# Altium schematic sheet trailGuard.SchDoc
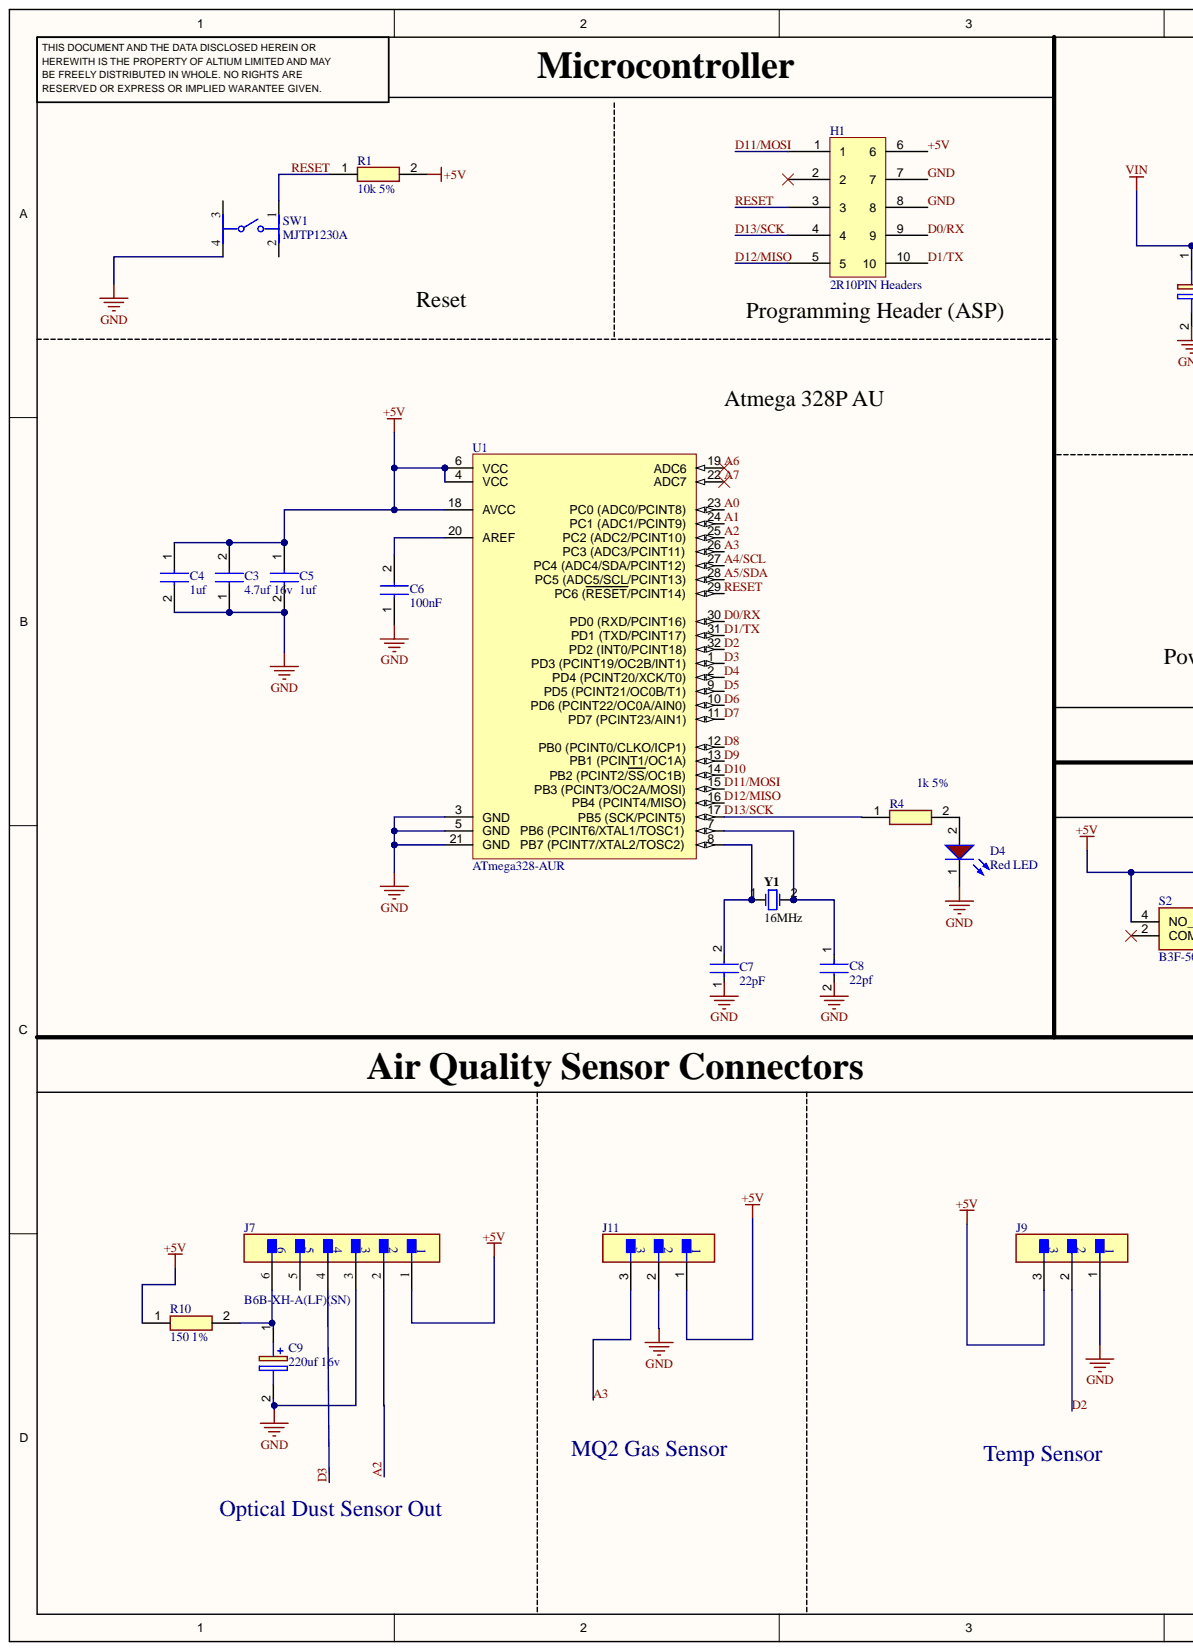
[diagram p0: trailGuard.SchDoc, left]
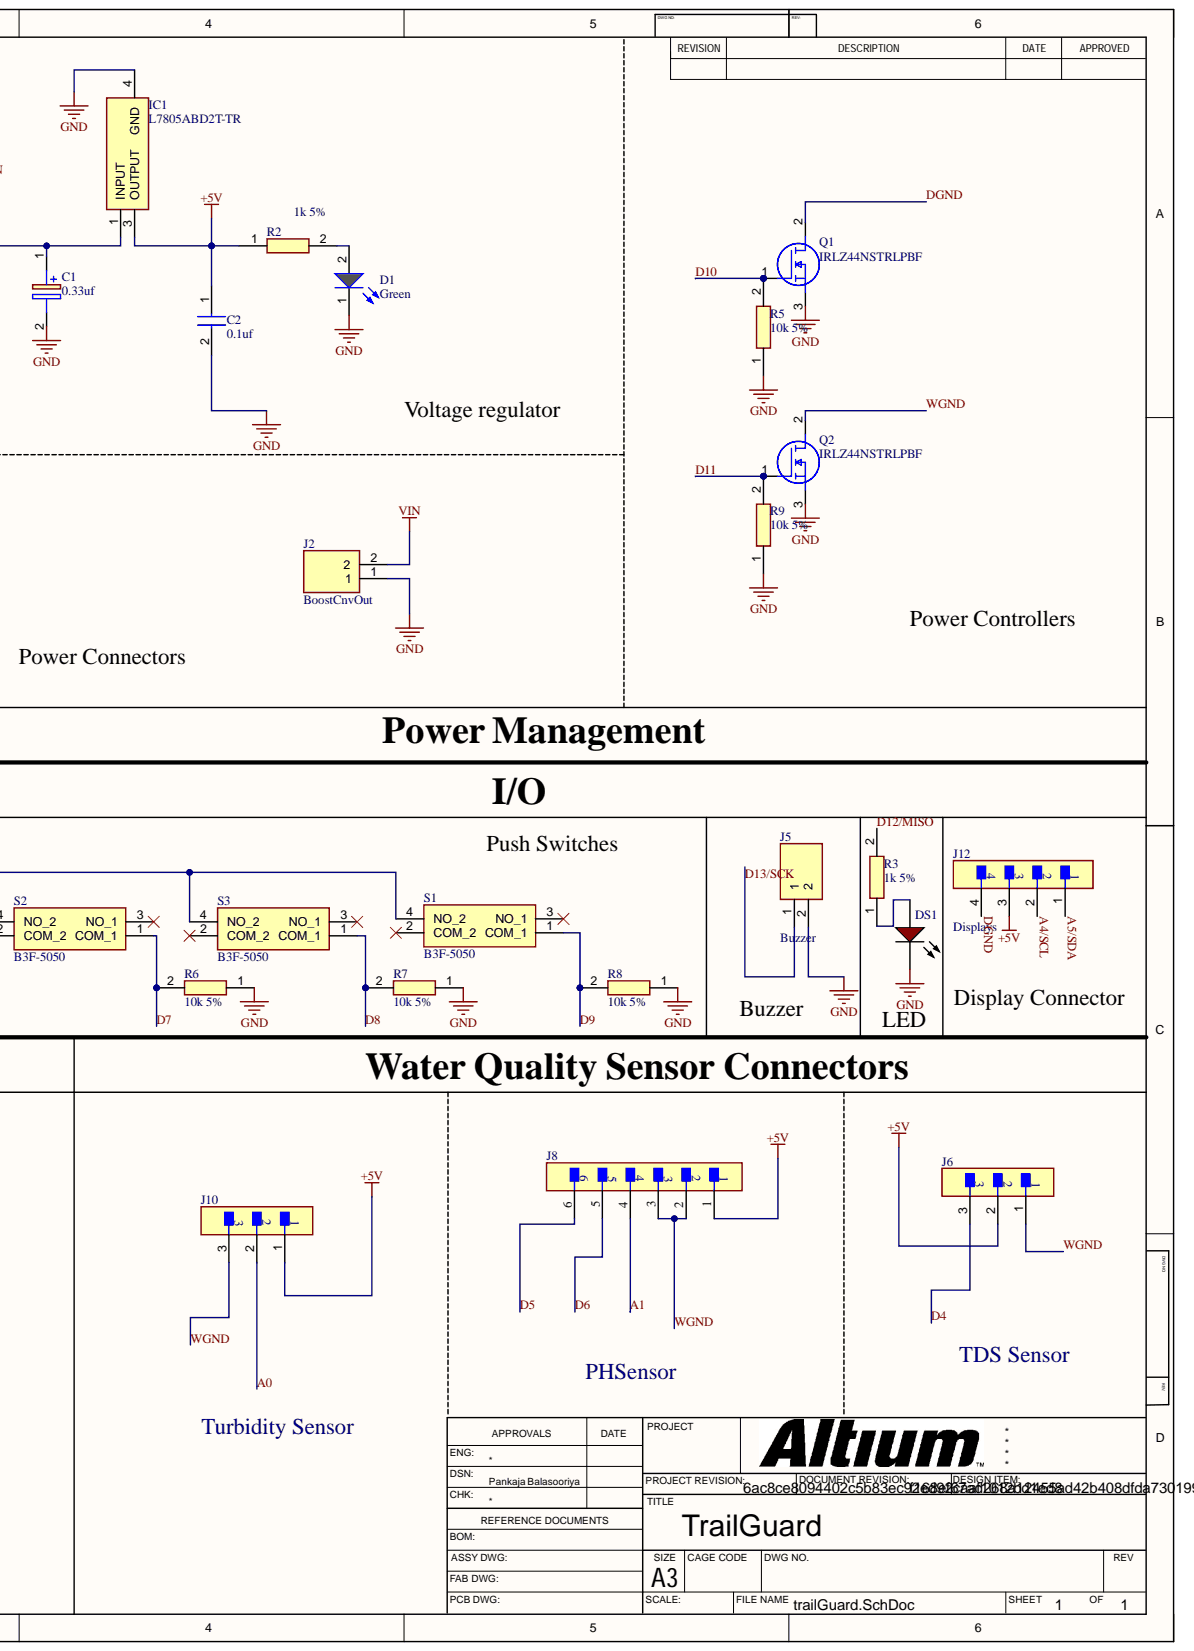
[diagram p1: trailGuard.SchDoc, right]

## Parts
C1  0.33uf  [CAPPM3216X180N]
C2  0.1uf  [C1206]
C3  4.7uf 16v  [CAPC3216X180N]
C4  1uf  [C1206]
C5  1uf  [C1206]
C6  100nF  [CAPC3216X190N]
C7  22pF  [FP-CL21-IPC_C]
C8  22pf  [FP-CL21-IPC_C]
C9  220uf 16v  [EEE0JA331XP]
D1  Green  [WL-SMCW_0805_150080xx75000]
D4  Red LED  Color: Red  [WL-SMCW_0805_150080xx75000]
DS1  HLMP-3301  [AVAG-HLMP-3301-2]  (value not drawn)
H1  2R10PIN Headers  [2R10PIN Headers]
IC1  L7805ABD2T-TR  [D2PAK-STB35NF10T4]
J2  BoostCnvOut  [B2BXHAMLFSN]
J5  Buzzer  [B2BXHAMLFSN]
J6  B3B-XH-A(LF)(SN)  [FP-B3B-XH-A_LF_SN-MFG]  (value not drawn)
J7  B6B-XH-A(LF)(SN)  [FP-B6B-XH-A_LF_SN-MFG]
J8  B6B-XH-A(LF)(SN)  [FP-B6B-XH-A_LF_SN-MFG]
J9  B3B-XH-A(LF)(SN)  [FP-B3B-XH-A_LF_SN-MFG]  (value not drawn)
J10  B3B-XH-A(LF)(SN)  [FP-B3B-XH-A_LF_SN-MFG]  (value not drawn)
J11  B3B-XH-A(LF)(SN)  [FP-B3B-XH-A_LF_SN-MFG]  (value not drawn)
J12  Displays  [FP-B4B-XH-AM_LF_SN-MFG]
Q1  IRLZ44NSTRLPBF  [IRF2804STRLPBF]
Q2  IRLZ44NSTRLPBF  [IRF2804STRLPBF]
R1  10k 5%  [RESC3116X70N]
R2  1k 5%  [RESC3116X70N]
R3  1k 5%  [RESC3116X70N]
R4  1k 5%  [RESC3116X70N]
R5  10k 5%  [RESC3116X70N]
R6  10k 5%  [RESC3116X70N]
R7  10k 5%  [RESC3116X70N]
R8  10k 5%  [RESC3116X70N]
R9  10k 5%  [RESC3116X70N]
R10  150 1%  [ERJ8ENF1000V]
S1  B3F-5050  [B3F-4055]
S2  B3F-5050  [B3F-4055]
S3  B3F-5050  [B3F-4055]
SW1  MJTP1230A  [FP-MJTP1230A-MFG]
U1  ATmega328-AUR  [32A_L]
Y1  16MHz  [FOX-FOXSLF160-20]

## Nets
VIN: C1.1 IC1.1 J2.2
+5V: C2.1 C3.2 C4.1 C5.1 H1.6 IC1.3 J6.2 J7.1 J8.1 J9.3 J10.1 J11.1 J12.3 R1.2 R2.1 R10.1 S1.4(NO_2) S2.4(NO_2) S3.4(NO_2) U1.4(VCC) U1.6(VCC) U1.18(AVCC)
A0: J10.2 U1.23
A1: J8.4 U1.24(PC1_(ADC1/PCINT9))
A2: J7.2 U1.25
A3: J11.3 U1.26(PC3_(ADC3/PCINT11))
A4/SCL: J12.2 U1.27(PC4_(ADC4/SDA/PCINT12))
A5/SDA: J12.1 U1.28(PC5_(ADC5/SCL/PCINT13))
A6: U1.19(ADC6)
A7: U1.22(ADC7)
D0/RX: H1.9 U1.30(PD0_(RXD/PCINT16))
D1/TX: H1.10 U1.31(PD1_(TXD/PCINT17))
D2: J9.2 U1.32(PD2_(INT0/PCINT18))
D3: J7.4 U1.1(PD3_(PCINT19/OC2B/INT1))
D4: J6.3 U1.2(PD4_(PCINT20/XCK/T0))
D5: J8.6 U1.9(PD5_(PCINT21/OC0B/T1))
D6: J8.5 U1.10(PD6_(PCINT22/OC0A/AIN0))
D7: R6.2 S2.1(COM_1) U1.11(PD7_(PCINT23/AIN1))
D8: R7.2 S3.1(COM_1) U1.12(PB0_(PCINT0/CLKO/ICP1))
D9: R8.2 S1.1(COM_1) U1.13(PB1_(PCINT1/OC1A))
D10: Q1.1 R5.2 U1.14(PB2_(PCINT2/SS/OC1B))
D11: Q2.1 R9.2
D11/MOSI: H1.1 U1.15(PB3_(PCINT3/OC2A/MOSI))
D12/MISO: H1.5 R3.2 U1.16(PB4_(PCINT4/MISO))
D13/SCK: H1.4 J5.1 R4.1 U1.17(PB5_(SCK/PCINT5))
DGND: J12.4 Q1.2
GND: C1.2 C2.2 C3.1 C4.2 C5.2 C6.1 C7.1 C8.2 C9.2 D1.1 D4.1 DS1.1 H1.7 H1.8 IC1.4 J2.1 J5.2 J7.3 J9.1 J11.2 Q1.3 Q2.3 R5.1 R6.1 R7.1 R8.1 R9.1 SW1.4 U1.3(GND) U1.5(GND) U1.21(GND)
RESET: H1.3 R1.1 SW1.1 U1.29(PC6_(RESET/PCINT14))
WGND: J6.1 J8.2 J8.3 J10.3 Q2.2
NetC6_2: C6.2 U1.20(AREF)
NetC7_2: C7.2 U1.8 Y1.1
NetC8_1: C8.1 U1.7 Y1.2
NetC9_1: C9.1 J7.6 R10.2
NetD1_2: D1.2 R2.2
NetD4_2: D4.2 R4.2
NetDS1_2: DS1.2 R3.1

Not connected: H1 2; J7 5; S1 2(COM_2) 3(NO_1); S2 2(COM_2) 3(NO_1); S3 2(COM_2) 3(NO_1); SW1 2 3

## Library data of the parts
C1 = 293D334X9035A2TE3: Capacitor Polarised; Manufacturer_Name: Vishay; Component Kind: Standard; Datasheet Link: http://www.vishay.com/docs/40002/293d.pdf; Height: 1.8mm; Manufacturer_Part_Number: 293D334X9035A2TE3; Mouser Part Number: 74-293D334X9035A2TE3; Mouser Price/Stock: https://www.mouser.co.uk/ProductDetail/Vishay-Sprague/293D334X9035A2TE3?qs=CH3Q5FKf4qBLTGJb7uLzfw%3D%3D
C2 C4 C5 = C1206C105K5RECTU: Capacitor; Manufacturer_Name: KEMET; Arrow Part Number: C1206C105K5RECTU; Arrow Price/Stock: https://www.arrow.com/en/products/c1206c105k5rectu/kemet-corporation; Component Kind: Standard; Datasheet Link: https://content.kemet.com/datasheets/KEM_C1090_X7R_ESD.pdf; Manufacturer_Part_Number: C1206C105K5RECTU; Mouser Part Number: 80-C1206C105K5RECTU; Mouser Price/Stock: https://www.mouser.co.uk/ProductDetail/KEMET/C1206C105K5RECTU?qs=55YtniHzbhAmzHye57%2FAnw%3D%3D
C3 = EMK316B7475KL-T: Capacitor; Manufacturer_Name: TAIYO YUDEN; Component Kind: Standard; Datasheet Link: https://componentsearchengine.com/Datasheets/2/EMK316B7475KL-T.pdf; Height: 1.8mm; Manufacturer_Part_Number: EMK316B7475KL-T; Mouser Part Number: 963-EMK316B7475KL-T; Mouser Price/Stock: http://www.mouser.com/Search/ProductDetail.aspx?qs=I6KAKw0tg2xhDY35w16PKg%3d%3d
C6 = MCJCU31LBB7104KTPA01: Capacitor; Manufacturer_Name: TAIYO YUDEN; Component Kind: Standard; Datasheet Link: https://ds.yuden.co.jp/TYCOMPAS/ap/specSheet?pn=MCJCU31LBB7104KTPA01; Height: 1.9mm; Manufacturer_Part_Number: MCJCU31LBB7104KTPA01; Mouser Part Number: 963-MCJCU31LBB7104KT; Mouser Price/Stock: https://www.mouser.co.uk/ProductDetail/TAIYO-YUDEN/MCJCU31LBB7104KTPA01?qs=tlsG%2FOw5FFgiqRcQEEePOw%3D%3D
C7 C8 = CMP-13271-000195-1: CL21 Series 0805 22pF 50V ±5% Tolerance C0G Multilayer Ceramic Chip Capacitor; Capacitance: 22pF; Case Code (Imperial): 0805; Case Code (Metric): 2012; Case/Package: 0805; Component Kind: Standard; Depth: 1.25mm; Dielectric: C0G; Height: 0.6mm; Length: 2mm; Library Name: Altium Content Vault; Max Operating Temperature: 125°C; Min Operating Temperature: -55°C; Mount: Surface Mount; Package Quantity: 1; Packaging: Tape and Reel; Radiation Hardening: No; Ratings: AEC-Q200; Thickness: 0.028inch; Tolerance: 5%; Voltage Rating: 50V; Width: 0.049inch
C9 = EEE-FTE221XAP: Capacitor Polarised; Manufacturer_Name: Panasonic; Arrow Part Number: EEE-FTE221XAP; Arrow Price/Stock: https://www.arrow.com/en/products/eeefte221xap/panasonic?region=nac; Component Kind: Standard; Datasheet Link: http://industrial.panasonic.com/cdbs/www-data/pdf/RDE0000/ABA0000C1240.pdf; Height: 7.8mm; Manufacturer_Part_Number: EEE-FTE221XAP; Mouser Part Number: 667-EEE-FTE221XAP; Mouser Price/Stock: https://www.mouser.co.uk/ProductDetail/Panasonic/EEE-FTE221XAP?qs=CMJjuEs1%252BuHWDEFaun6NQg%3D%3D
D1 = CMP-1426-00007-2: NexarPartId: 29717780; Dominant Wavelength: 525nm; Forward Current: 100mA; Forward Voltage: 3.2V; Lens Style: Clear, Rectangular; Luminous Intensity: 450mcd; Max Junction Temperature (Tj): 95°C; Max Reverse Voltage (DC): 5V; Peak Wavelength: 515nm; Power Dissipation: 105mW; Test Current: 20mA; Viewing Angle: 140°; Wavelength: 520nm; Case Code (Imperial): 0805; Case Code (Metric): 2012; Case/Package: 0805; Color: Green; Component Kind: Standard; Height: 700µm; Length: 2mm; Library Name: Altium Content Vault; Max Operating Temperature: 85°C; Min Operating Temperature: -40°C; Mount: Surface Mount; Packaging: Tape and Reel; REACH SVHC: Unknown; Reverse Voltage: 5V; RoHS: Compliant; Width: 1.25mm
D4 = CMP-1426-00011-2: NexarPartId: 29717781; Dominant Wavelength: 625nm; Forward Current: 100mA; Forward Voltage: 2V; Lens Style: Clear, Rectangular; Luminous Intensity: 150mcd; Max Reverse Voltage (DC): 5V; Peak Wavelength: 630nm; Test Current: 20mA; Viewing Angle: 140°; Case Code (Imperial): 0805; Case Code (Metric): 2012; Case/Package: 0805; Component Kind: Standard; Height: 700µm; Length: 2mm; Library Name: Altium Content Vault; Max Operating Temperature: 85°C; Min Operating Temperature: -40°C; Mount: Surface Mount; Packaging: Tape and Reel; REACH SVHC: Unknown; RoHS: Compliant; Wavelength: 625nm; Width: 1.25mm
DS1 = CMP-2000-06411-1: T-1 3/4 (5 mm) Diffused LED Lamp, 1.9 V, -40 to 100 degC, 2-Pin THD, RoHS, Bulk; Manufacturer: Avago; Chip Material: GaP; DELETED: Compliant; Dominant Wave Length: 626nm; Emitting Color: Red; Forward Current: 25mA; Forward Voltage: 2.4V; High Brightness: No; Lens Type: ColoredDiffused; Lum Intensity: 5.4mcd; Main Category: StandardLED; Number of  Elements: 1; Peak Wavelength: 635nm; Power Dissipation: 85W; Product Depth (mm): 6.1mm; Product Height (mm): 9.19mm; Reverse Voltage: 5V; Shape Type: Circular; Test Current (It): 10mA; Type: Uni-Color; Viewing Angle: 60deg; Component Kind: Standard; Datasheet URL: http://www.avagotech.com/docs/AV02-1558EN; Datasheet Version: 03/2015; Lens Dimensions: 5.08x5.08x8.3mm; Library Name: Altium Content Vault; Luminous Intensity: 5.4mcd; Manufacturer URL: http://www.avagotech.com/; Mounting: ThroughHole; Mounting Technology: ThroughHole; Operating Temp Range: -40Cto100C; Operating Temperature Classification: Industrial; Package Description: 2-Pin Through Hole LED, Dia 5.85 mm; Package Reference: HLMP-3301; Package Type: T-13/4; Packaging: TapeandReel; Pin Count: 2; Product Length (mm): 6.1mm; Rad Hardened: No
H1 = 2R10PIN Headers: PCB Mount Header, Vertical, Board-to-Board, 10 Position, 2.54 mm [.1 in] Centerline, Breakaway, Tin, Through Hole - Solder, Signal, AMPMODU Headers; Component Kind: Standard; Library Name: trailGuard.SchLib
IC1 = L7805ABD2T-TR: Integrated Circuit; Manufacturer_Name: STMicroelectronics; Arrow Part Number: L7805ABD2T-TR; Arrow Price/Stock: https://www.arrow.com/en/products/l7805abd2t-tr/stmicroelectronics?region=nac; Component Kind: Standard; Datasheet Link: https://www.st.com/resource/en/datasheet/l78.pdf; Manufacturer_Part_Number: L7805ABD2T-TR; Mouser Part Number: 511-L7805ABD2T-TR; Mouser Price/Stock: https://www.mouser.co.uk/ProductDetail/STMicroelectronics/L7805ABD2T-TR?qs=LL%252BsqOK9SqOQ%2FfZD5ZsNqA%3D%3D
J2 J5 = B2B-XH-AM_LF__SN_: Connector; Manufacturer_Name: JST (JAPAN SOLDERLESS TERMINALS); Manufacturer_Part_Number: B2B-XH-AM(LF)(SN); Arrow Part Number: B2B-XH-AM(LF)(SN); Arrow Price/Stock: https://www.arrow.com/en/products/b2b-xh-am-lf-sn/jst-manufacturing?region=europe; Component Kind: Standard; Datasheet Link: https://www.jst-mfg.com/product/pdf/eng/eXH.pdf; Height: 7mm
J6 J9-J11 = CMP-17439-000014-3: CONN HEADER VERT 3POS 2.5MM; Contact Resistance: 20mR; Current Rating: 3A; Gender: Male; Insulation Resistance: 1GR; Max Voltage Rating (AC): 250V; Number of Contacts: 3; Wire/Cable Gauge: 22AWG; Color: Natural; Component Kind: Standard; Connector Type: Header; Contact Finish - Mating: TIN; Contact Material: Brass; Contact Plating: Tin; Contact Shape: Square; Contact Type: Male Pin; Depth: 5.75mm; Fastening Type: Detent; Flammability Rating: UL94 V-0; Housing Color: White; Housing Material: Polyamide; Insulation Color: Natural; Insulation Material: Nylon; Lead Free: Lead Free; Lead Pitch: 2.5mm; Length: 9.9mm; Library Name: Altium Content Vault; Max Current Rating: 3A; Max Operating Temperature: 85°C; Max Voltage Rating (DC): 250V; Min Operating Temperature: -25°C; Mount: Through Hole; Number of Positions: 3; Number of Rows: 1; Orientation: Straight; Packaging: Bulk; Pitch: 2.5mm; REACH SVHC: No SVHC; Series: XH; Termination: Solder; Voltage Rating: 250V; Voltage Rating (AC): 250V
J7 J8 = CMP-17439-000076-1: CONN HEADER VERT 6POS 2.5MM; Component Kind: Standard; Library Name: Altium Content Vault
J12 = CMP-17439-000301-1: CONN HEADER VERT 4POS 2.5MM; Component Kind: Standard; Library Name: Altium Content Vault
Q1 Q2 = IRLZ44NSTRLPBF: MOSFET (N-Channel); Manufacturer_Name: Infineon; Arrow Part Number: IRLZ44NSTRLPBF; Arrow Price/Stock: https://www.arrow.com/en/products/irlz44nstrlpbf/infineon-technologies-ag?region=nac; Component Kind: Standard; Datasheet Link: https://www.infineon.com/dgdl/irlz44nspbf.pdf?fileId=5546d462533600a40153567221272727; Height: 4.83mm; Manufacturer_Part_Number: IRLZ44NSTRLPBF; Mouser Part Number: 942-IRLZ44NSTRLPBF; Mouser Price/Stock: https://www.mouser.co.uk/ProductDetail/Infineon-Technologies/IRLZ44NSTRLPBF?qs=9%252BKlkBgLFf1LijFJvUznEg%3D%3D
R1 R5-R9 = ERJ-8GEYJ103V: Resistor; Manufacturer_Name: Panasonic; Arrow Part Number: ERJ-8GEYJ103V; Arrow Price/Stock: https://www.arrow.com/en/products/erj-8geyj103v/panasonic?region=nac; Component Kind: Standard; Datasheet Link: https://4donline.ihs.com/images/VipMasterIC/IC/PANA/PANA-S-A0009891627/PANA-S-A0009891627-1.pdf?hkey=52A5661711E402568146F3353EA87419; Height: 0.7mm; Manufacturer_Part_Number: ERJ-8GEYJ103V; Mouser Part Number: 667-ERJ-8GEYJ103V; Mouser Price/Stock: https://www.mouser.co.uk/ProductDetail/Panasonic/ERJ-8GEYJ103V?qs=IZrxGxQQvkNYuW0TYiASIA%3D%3D
  R1 R5 R9: Library Name: SamacSys.SchLib
R2-R4 = ERJ-T08J102V: Resistor; Manufacturer_Name: Panasonic; Arrow Part Number: ERJ-T08J102V; Arrow Price/Stock: https://www.arrow.com/en/products/erj-t08j102v/panasonic?region=nac; Component Kind: Standard; Datasheet Link: https://datasheet.datasheetarchive.com/originals/distributors/Datasheets_SAMA/36012e4a716ec4a09ea551adc0f8ad50.pdf; Height: 0.7mm; Manufacturer_Part_Number: ERJ-T08J102V; Mouser Part Number: 667-ERJ-T08J102V; Mouser Price/Stock: https://www.mouser.co.uk/ProductDetail/Panasonic/ERJ-T08J102V?qs=iIVTEDlrHA1ZEpy1PD9K4g%3D%3D
R10 = ERJ-8ENF1500V: Resistor; Manufacturer_Name: Panasonic; Arrow Part Number: ERJ-8ENF1500V; Arrow Price/Stock: https://www.arrow.com/en/products/erj-8enf1500v/panasonic?region=nac; Component Kind: Standard; Datasheet Link: https://industrial.panasonic.com/cdbs/www-data/pdf/RDA0000/AOA0000C86.pdf; Height: 0.7mm; Manufacturer_Part_Number: ERJ-8ENF1500V; Mouser Part Number: 667-ERJ-8ENF1500V; Mouser Price/Stock: https://www.mouser.co.uk/ProductDetail/Panasonic/ERJ-8ENF1500V?qs=JjxTDIFmKPTW8QBP1YAtHw%3D%3D
S1-S3 = B3F-5050: Switch; Manufacturer_Name: Omron Electronics; Arrow Part Number: B3F-5050; Arrow Price/Stock: https://www.arrow.com/en/products/b3f5050/omron?region=nac; Component Kind: Standard; Datasheet Link: https://omronfs.omron.com/en_US/ecb/products/pdf/en-b3f.pdf; Manufacturer_Part_Number: B3F-5050; Mouser Part Number: 653-B3F-5050; Mouser Price/Stock: https://www.mouser.co.uk/ProductDetail/Omron-Electronics/B3F-5050?qs=dOLq8QE0Pqqk%2FO9x2OpTQw%3D%3D
SW1 = CMP-47024-000004-1: SWITCH TACTILE SPST-NO 0.05A 12V; Actuator Color: Black; Actuator Length: 1.4mm; Actuator Material: Thermoplastic; Actuator Type: Standard; Contact Resistance: 100mR; Current Rating: 50mA; Electrical Life: 100000Cycles; Illumination Type: Non-Illuminated; Operating Force: 160gf; Switch Function: Off-Mom; Switch Travel: 0.25mm; Throw Configuration: SPST; Color: Black; Component Kind: Standard; Contact Material: Bronze; Contact Plating: Silver; Library Name: Altium Content Vault; Max Operating Temperature: 70°C; Max Voltage Rating (DC): 12V; Mechanical Life: 100000Cycles; Min Operating Temperature: -20°C; Mount: Through Hole; Orientation: Straight; Package Quantity: 2000; Packaging: Bulk; REACH SVHC: No SVHC; RoHS Compliant: Yes; Series: MJTP; Termination: PC Pin; Voltage Rating (DC): 12V
U1 = CMP-0095-00268-2: 8-bit AVR Microcontroller, 32KB Flash, 1KB EEPROM, 2KB SRAM, 32-pin TQFP, Industrial Grade (-40°C to 85°C), Reel; Code_JEDEC: MS-026-ABA; Component Kind: Standard; ComponentLink1Description: Manufacturer URL; ComponentLink1URL: http://www.atmel.com/; ComponentLink2Description: ATmega48A/48PA/88A/88PA/168A/168PA/328/328P Datasheet; ComponentLink2URL: http://www.atmel.com/dyn/resources/prod_documents/doc8271.pdf; ComponentLink3Description: ATmega48A/48PA/88A/88PA/168A/168PA/328/328P Summary; ComponentLink3URL: http://www.atmel.com/dyn/resources/prod_documents/8271S.pdf; DatasheetVersion: revD, May-2011; Library Name: Altium Content Vault; PackageDescription: 32-Lead, 7x7x1.0 mm Body, 0.8mm Lead Pitch, Thin Profile Plastic Quad Flat Package (TQFP); PackageReference: 32A; PackageVersion: revC, Oct-2010; RevisionNote: DatasheetVersion & PackageVersion updated. URLs added.
Y1 = CMP-1749-00003-1: Resistance Weld Thru-Hole Crystal, 16 MHz, -20 to 70 degC, 2-Pin THD, RoHS, Bulk; Case\Package: Axial; Power Rating: 250 mW; Resistance: 221 kohm; Tolerance: 1%; Voltage Rating: 250 V; Component Kind: Standard; ComponentLink1Description: Manufacturer URL; ComponentLink1URL: http://www.foxonline.com/; ComponentLink2Description: Datasheet; ComponentLink2URL: http://www.foxonline.com/pdfs/hc49slf.pdf; DatasheetVersion: Mar-15; Length: 0.248 inch; Library Name: Altium Content Vault; Max Operating Temperature: 155 degC; Min Operating Temperature: -55 degC; Mount: Through Hole; Package Quantity: 200; PackageDescription: 2-Pin Through Hole Device, Body 11.35 x 4.65 mm; PackageReference: FOXSLF160-20; Packaging: Bulk; RoHSCompliant: true
